# Altium schematic sheet SENSOR_and_Input_Output V1.0.SchDoc("SENSOR_and_Input_Output V1.0")
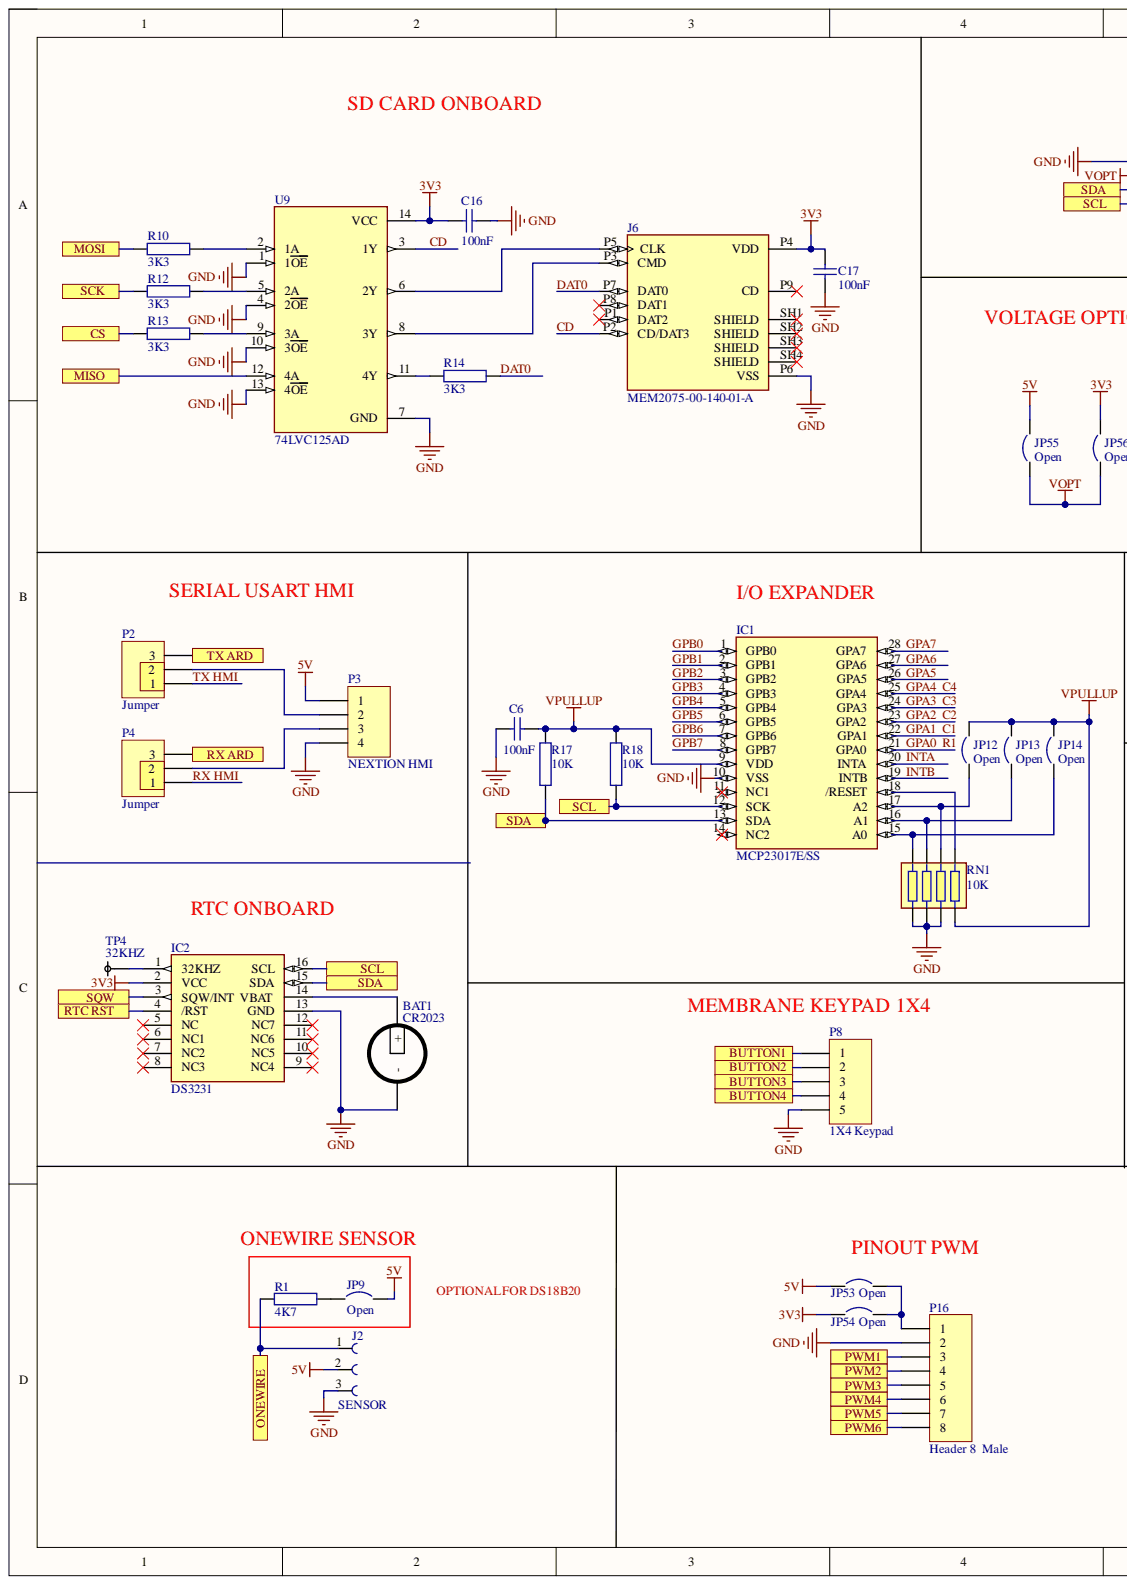
[diagram p0: SENSOR_and_Input_Output V1.0.SchDoc, left]
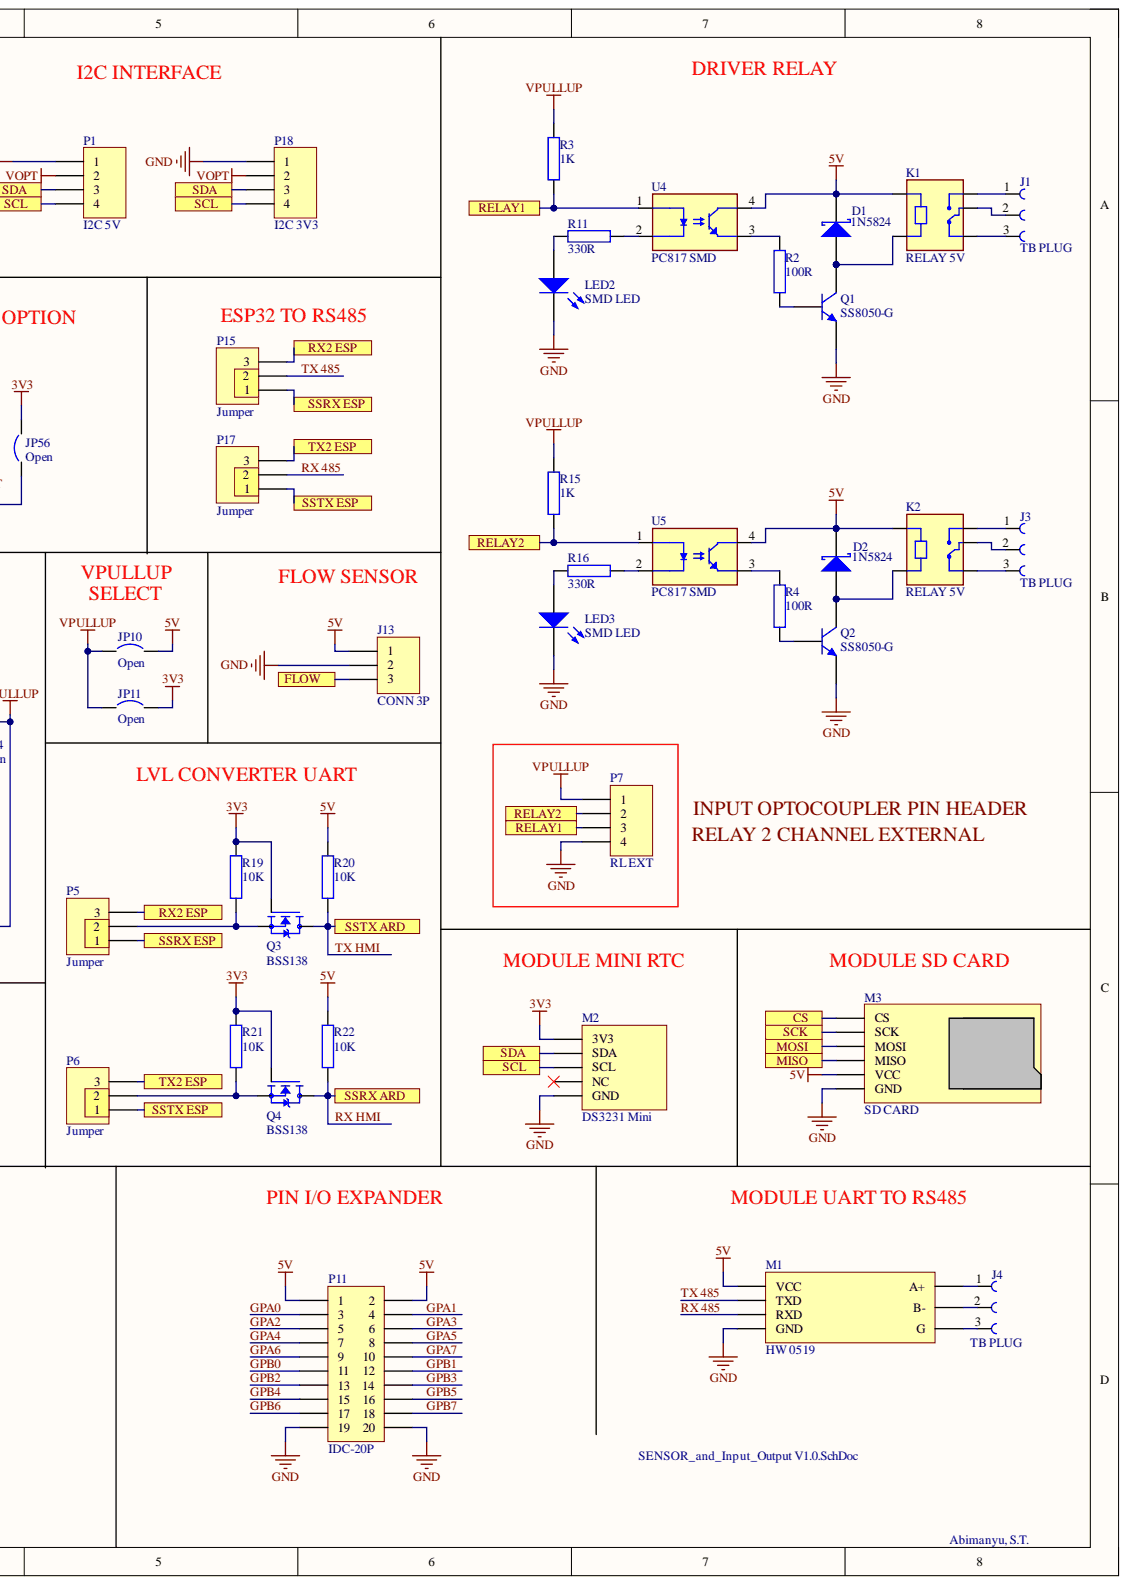
[diagram p1: SENSOR_and_Input_Output V1.0.SchDoc, right]

## Parts
BAT1  CR2023  [BATT CR2023 VERTICAL]
C6  100nF  [CAP-0805_Capacitor Non Polar]
C16  100nF  [CAP-0805_Capacitor Non Polar]
C17  100nF  [CAP-0805_Capacitor Non Polar]
D1  1N5824  [DO-214AC]
D2  1N5824  [DO-214AC]
IC1  MCP23017E/SS  [SSOP-28]
IC2  DS3231  [SOIC-16]
J1  TB PLUG  [TB PLUG 3P 5mm]
J2  SENSOR  [TB PLUG 3P 5mm]
J3  TB PLUG  [TB PLUG 3P 5mm]
J4  TB PLUG  [TB PLUG 3P 5mm]
J6  MEM2075-00-140-01-A  [GCT_MEM2075-00-140-01-A]
J13  CONN 3P  [CONN HDR 3P TH]
JP9  Open  [SB_STM]
JP10  Open  [SB_STM]
JP11  Open  [SB_STM]
JP12  Open  [SB_STM]
JP13  Open  [SB_STM]
JP14  Open  [SB_STM]
JP53  Open  [SB_STM]
JP54  Open  [SB_STM]
JP55  Open  [SB_STM]
JP56  Open  [SB_STM]
K1  RELAY 5V  [RELAY_SONGLE-05VDC-SL-C]
K2  RELAY 5V  [RELAY_SONGLE-05VDC-SL-C]
LED2  SMD LED  [LED SMT 1608 (0603)]
LED3  SMD LED  [LED SMT 1608 (0603)]
M1  HW 0519  [HW 0519]
M2  DS3231 Mini  [Module DS3231 Mini RTC RIVERSED]
M3  SD CARD  [MODULE SD CARD]
P1  I2C 5V  [CONN HDR 4P TH]
P2  Jumper  [Jumper Pin, 3-Pin]
P3  NEXTION HMI  [CONN HDR 4P TH]
P4  Jumper  [Jumper Pin, 3-Pin]
P5  Jumper  [Jumper Pin, 3-Pin]
P6  Jumper  [Jumper Pin, 3-Pin]
P7  RL EXT  [Pin Header 1x4 TH Pitch 2.54mm]
P8  1X4 Keypad  [Pin Header 1x5 TH Pitch 2.54mm]
P11  IDC-20P  [IDC-20P]
P15  Jumper  [Jumper Pin, 3-Pin]
P16  Header 8  Male  [Pin Header 1x8 TH Pitch 2.54mm]
P17  Jumper  [Jumper Pin, 3-Pin]
P18  I2C 3V3  [CONN HDR 4P TH]
Q1  SS8050-G  [TO-236]
Q2  SS8050-G  [TO-236]
Q3  BSS138  [SOT-23]
Q4  BSS138  [SOT-23]
R1  4K7  [1608_[0603] Resistor]
R2  100R  [1608_[0603] Resistor]
R3  1K  [1608_[0603] Resistor]
R4  100R  [1608_[0603] Resistor]
R10  3K3  [RESITOR 0805]
R11  330R  [1608_[0603] Resistor]
R12  3K3  [RESITOR 0805]
R13  3K3  [RESITOR 0805]
R14  3K3  [RESITOR 0805]
R15  1K  [1608_[0603] Resistor]
R16  330R  [1608_[0603] Resistor]
R17  10K  [RESITOR 0805]
R18  10K  [RESITOR 0805]
R19  10K  [RESITOR 0805]
R20  10K  [RESITOR 0805]
R21  10K  [RESITOR 0805]
R22  10K  [RESITOR 0805]
RN1  10K  [RES 4ARR 0603]
TP4  32KHZ  [PAD_HOLE]
U4  PC817 SMD  [SMD-4]
U5  PC817 SMD  [SMD-4]
U9  74LVC125AD  [SOIC14]

## Ports
BUTTON1, BUTTON2, BUTTON3, BUTTON4, CS, FLOW, MISO, MOSI, ONEWIRE, PWM1, PWM2, PWM3, PWM4, PWM5, PWM6, RELAY1, RELAY2, RTC RST, RX2 ESP, RX ARD, SCK, SCL, SDA, SQW, SSRX ARD, SSRX ESP, SSTX ARD, SSTX ESP, TX2 ESP, TX ARD

## Nets
3V3: C16.2 C17.2 IC2.2(VCC) J6.P4(VDD) JP11.2 JP54.1 JP56.2 M2.5(3V3) Q3.1 Q4.1 R19.2 R21.2 U9.14(VCC)
5V: D1.C D2.C J2.2 J13.1 JP9.2 JP10.2 JP53.1 JP55.2 K1.A1 K2.A1 M1.VCC M3.VCC P3.1 P11.1 P11.2 R20.2 R22.2 U4.4 U5.4
GND: BAT1.- C6.1 C16.1 C17.1 IC1.10(VSS) IC2.13(GND) J2.3 J6.P6(VSS) J13.2 LED2.2 LED3.2 M1.GND M2.1(GND) M3.GND P1.1 P3.4 P7.4 P8.5 P11.19 P11.20 P16.2 P18.1 Q1.2 Q2.2 RN1.1 RN1.2 RN1.3 U9.1(1OE) U9.4(2OE) U9.7(GND) U9.10(3OE) U9.13(4OE)
VOPT: JP55.1 JP56.1 P1.2 P18.2
VPULLUP: C6.2 IC1.9(VDD) JP10.1 JP11.1 JP12.2 JP13.2 JP14.2 P7.1 R3.1 R15.1 R17.2 R18.2 RN1.4
BUTTON1: P8.1 ; port BUTTON1
BUTTON2: P8.2 ; port BUTTON2
BUTTON3: P8.3 ; port BUTTON3
BUTTON4: P8.4 ; port BUTTON4
C1: IC1.22(GPA1) P11.4
C2: IC1.23(GPA2) P11.5
C3: IC1.24(GPA3) P11.6
C4: IC1.25(GPA4) P11.7
CD: J6.P2(CD/DAT3) U9.3(1Y)
CS: M3.CS R13.1 ; port CS
DAT0: J6.P7(DAT0) R14.2
FLOW: J13.3 ; port FLOW
GPA0: IC1.21(GPA0) P11.3
GPA5: IC1.26(GPA5) P11.8
GPA6: IC1.27(GPA6) P11.9
GPA7: IC1.28(GPA7) P11.10
GPB0: IC1.1(GPB0) P11.11
GPB1: IC1.2(GPB1) P11.12
GPB2: IC1.3(GPB2) P11.13
GPB3: IC1.4(GPB3) P11.14
GPB4: IC1.5(GPB4) P11.15
GPB5: IC1.6(GPB5) P11.16
GPB6: IC1.7(GPB6) P11.17
GPB7: IC1.8(GPB7) P11.18
INTA: IC1.20(INTA)
INTB: IC1.19(INTB)
MISO: M3.MISO U9.12(4A) ; port MISO
MOSI: M3.MOSI R10.1 ; port MOSI
ONEWIRE: J2.1 R1.1 ; port ONEWIRE
PWM1: P16.3 ; port PWM1
PWM2: P16.4 ; port PWM2
PWM3: P16.5 ; port PWM3
PWM4: P16.6 ; port PWM4
PWM5: P16.7 ; port PWM5
PWM6: P16.8 ; port PWM6
RELAY1: P7.3 R3.2 U4.1 ; port RELAY1
RELAY2: P7.2 R15.2 U5.1 ; port RELAY2
RTC RST: IC2.4(/RST) ; port RTC RST
RX2 ESP: P5.3 P15.3 ; port RX2 ESP
RX 485: M1.RXD P17.2
RX ARD: P4.3 ; port RX ARD
RX HMI: P4.1 Q4.3 R22.1 ; port SSRX ARD
SCK: M3.SCK R12.1 ; port SCK
SCL: IC1.12(SCK) IC2.16(SCL) M2.3(SCL) P1.4 P18.4 R18.1 ; port SCL
SDA: IC1.13(SDA) IC2.15(SDA) M2.4(SDA) P1.3 P18.3 R17.1 ; port SDA
SQW: IC2.3(SQW/INT) ; port SQW
SSRX ESP: P5.1 P15.1 ; port SSRX ESP
SSTX ESP: P6.1 P17.1 ; port SSTX ESP
TX2 ESP: P6.3 P17.3 ; port TX2 ESP
TX 485: M1.TXD P15.2
TX ARD: P2.3 ; port TX ARD
TX HMI: P2.1 Q3.3 R20.1 ; port SSTX ARD
NetBAT1_+: BAT1.+ IC2.14(VBAT)
NetD1_A: D1.A K1.A2 Q1.3
NetD2_A: D2.A K2.A2 Q2.3
NetIC1_15: IC1.15(A0) JP14.1 RN1.8
NetIC1_16: IC1.16(A1) JP13.1 RN1.7
NetIC1_17: IC1.17(A2) JP12.1 RN1.6
NetIC1_18: IC1.18(/RESET) RN1.5
NetIC2_1: IC2.1(32KHZ) TP4.1
NetJ1_1: J1.1 K1.NO
NetJ1_2: J1.2 K1.COM
NetJ1_3: J1.3 K1.NC
NetJ3_1: J3.1 K2.NO
NetJ3_2: J3.2 K2.COM
NetJ3_3: J3.3 K2.NC
NetJ4_1: J4.1 M1.a+(A+)
NetJ4_2: J4.2 M1.B-
NetJ4_3: J4.3 M1.485(G)
NetJ6_P3: J6.P3(CMD) U9.8(3Y)
NetJ6_P5: J6.P5(CLK) U9.6(2Y)
NetJP9_1: JP9.1 R1.2
NetJP53_2: JP53.2 JP54.2 P16.1
NetLED2_1: LED2.1 R11.2
NetLED3_1: LED3.1 R16.2
NetP2_2: P2.2 P3.2
NetP3_3: P3.3 P4.2
NetP5_2: P5.2 Q3.2 R19.1
NetP6_2: P6.2 Q4.2 R21.1
NetQ1_1: Q1.1 R2.1
NetQ2_1: Q2.1 R4.1
NetR2_2: R2.2 U4.3
NetR4_2: R4.2 U5.3
NetR10_2: R10.2 U9.2(1A)
NetR11_1: R11.1 U4.2
NetR12_2: R12.2 U9.5(2A)
NetR13_2: R13.2 U9.9(3A)
NetR14_1: R14.1 U9.11(4Y)
NetR16_1: R16.1 U5.2

Not connected: IC1 11(NC1) 14(NC2); IC2 5(NC) 6(NC1) 7(NC2) 8(NC3) 9(NC4) 10(NC5) 11(NC6) 12(NC7); J6 P1(DAT2) P8(DAT1) P9(CD) SH1(SHIELD) SH2(SHIELD) SH3(SHIELD) SH4(SHIELD); M2 2(NC)

## Library data of the parts
BAT1 = BATT CR2023 VERTICAL: BATTERY HOLDER; Manufacturer: Keystone; Part Number: 1056; Batt Size: CR1220; Component Kind: Standard; Library Name: AB_Lib.SchLib; PackageReference: Header Double Pin
C6 C16 C17 = Capacitor 0805 (Inch): 0805 SMD Non-Polarity Capacitor; Component Kind: Standard; Library Name: AB_Lib.SchLib
D1 D2 = 1N5824 SMD: Maximum repetitive peak reverse voltage 40v  Maximum average forward rectified current 5.0A  Peak forward surge current 8.3 mS 100A  Voltage rate of change 10000 V/uS  Operating junction temperature range -55 to +150 C; MF: Diodes Incorporated; MP: US1M-13; Availability: In Stock; Check_prices: https://www.snapeda.com/parts/US1M-13/Diodes+Inc./view-part/?ref=eda; Component Kind: Standard; Library Name: AB_Lib.SchLib; Package: DO-214AC-214 Diodes Incorporated; Price: None; SnapEDA_Link: https://www.snapeda.com/parts/US1M-13/Diodes+Inc./view-part/?ref=snap
IC1 = MCP23017E/SS: I/O Expander 16 IÂ²C 10MHz 28-SSOP; MF: Microchip Technology; MP: MCP23017-E/SS; Availability: In Stock; Check_prices: https://www.snapeda.com/parts/MCP23017-E/SS/Microchip/view-part/5532973/?ref=eda; Component Kind: Standard; Library Name: AB_Lib.SchLib; Package: SSOP-28 Microchip; Price: None; SnapEDA_Link: https://www.snapeda.com/parts/MCP23017-E/SS/Microchip/view-part/5532973/?ref=snap
IC2 = DS3231: Real Time Clock (RTC) IC Clock/Calendar - IÂ²C, 2-Wire Serial 8-SOIC (0.154, 3.90mm Width); MF: Maxim Integrated; Availability: In Stock; Check_prices: https://www.snapeda.com/parts/DS3231/Maxim+Integrated/view-part/?ref=eda; Component Kind: Standard; Library Name: AB_Lib.SchLib; MP: DS3231; Package: SOIC-16 Maxim Integrated; Price: None; Purchase-URL: https://pricing.snapeda.com/search/part/DS3231/?ref=eda; SnapEDA_Link: https://www.snapeda.com/parts/DS3231/Maxim+Integrated/view-part/?ref=snap
J1-J4 = TB PLUG 3P 5M: MF: Wurth Elektronik; MP: 691312710002; Availability: In Stock; Check_prices: https://www.snapeda.com/parts/691312710002/Wurth+Elektronik/view-part/?ref=eda; Component Kind: Standard; Description: TB PLUG 3P 5M; Library Name: AB_Lib.SchLib; Package: None; Price: None; Purchase-URL: https://pricing.snapeda.com/search/part/691312710002/?ref=eda; SnapEDA_Link: https://www.snapeda.com/parts/691312710002/Wurth+Elektronik/view-part/?ref=snap
J6 = MEM2075-00-140-01-A: Micro SD Memory Card Connector, 1.4mm Profile SMT, Push-Push, with Normally open Switch; Availability: Unavailable; Component Kind: Standard; Library Name: AB_Lib.SchLib; MP: MEM2075-00-140-01-A; Package: None; Price: None
J13 = CONN HDR 3P TH: Connector Header Through Hole 3 position 0.098 (2.50mm); Component Kind: Standard; LatestRevisionDate: 17-Jul-2002; LatestRevisionNote: Re-released for DXP Platform.; Library Name: AB_Lib.SchLib; Publisher: Altium Limited
JP9-JP14 JP53-JP56 = Jumper Solder: Jumper Solder Pad; Component Kind: Standard; Library Name: AB_Lib.SchLib; Published: 24-Mar-1999; Revision: July-2002: Re-released for DXP Platform.; Publisher: Altium Limited
K1 K2 = RELAY SONGLE 5V: 5V Trigger Relay Module For Arduino And Raspberry Pi 5V Trigger Relay Module For Arduino And Raspberry Pi; MF: Songle Relay; MP: SRD-05VDC-SL-C; Availability: Not in stock; Check_prices: https://www.snapeda.com/parts/SRD-05VDC-SL-C/Songle+Relay/view-part/?ref=eda; Component Kind: Standard; Library Name: AB_Lib.SchLib; Package: NON STANDARD-5 Songle Relay; Price: None; SnapEDA_Link: https://www.snapeda.com/parts/SRD-05VDC-SL-C/Songle+Relay/view-part/?ref=snap
LED2 LED3 = LED SMT BLUE: 0603 BLUE LED; Component Kind: Standard; LatestRevisionDate: 08-Jul-2005; LatestRevisionNote: Mech Layer 1 removed.; Library Name: AB_Lib.SchLib; PackageDescription: LED; 2 Leads; PackageReference: LED-0; Published: 8-Jun-2000; Publisher: Altium Limited
M1 = HW 0519: RS485 TO UART; Component Kind: Standard; LatestRevisionDate: 17-Jul-2002; LatestRevisionNote: Re-released for DXP Platform.; Library Name: AB_Lib.SchLib; Publisher: Altium Limited
M2 = Module RIVERSED DS3231 Mini RTC for Pi: Mini RTC; Component Kind: Standard; LatestRevisionDate: 17-Jul-2002; LatestRevisionNote: Re-released for DXP Platform.; Library Name: AB_Lib.SchLib; Publisher: Altium Limited
M3 = Module SD CARD: Component Kind: Standard; Description: Module SD CARD; LatestRevisionDate: 17-Jul-2002; LatestRevisionNote: Re-released for DXP Platform.; Library Name: AB_Lib.SchLib; Publisher: Altium Limited
P1 P3 P18 = CONN HDR 4P TH: CONN HEADER 4P TH; Component Kind: Standard; LatestRevisionDate: 17-Jul-2002; LatestRevisionNote: Re-released for DXP Platform.; Library Name: AB_Lib.SchLib; Publisher: Altium Limited
P2 P4-P6 P15 P17 = Jumper Pin, 3-Pin: Component Kind: Standard; Description: Jumper Pin, 3-Pin; LatestRevisionDate: 17-Jul-2002; LatestRevisionNote: Re-released for DXP Platform.; Library Name: AB_Lib.SchLib; Publisher: Altium Limited
P7 = Header 4  Male: Header, 4-Pin; Component Kind: Standard; LatestRevisionDate: 17-Jul-2002; LatestRevisionNote: Re-released for DXP Platform.; Library Name: AB_Lib.SchLib; Publisher: Altium Limited
P8 = Header 5  Male: Header, 5-Pin; Component Kind: Standard; LatestRevisionDate: 17-Jul-2002; LatestRevisionNote: Re-released for DXP Platform.; Library Name: AB_Lib.SchLib; Publisher: Altium Limited
P16 = Header 8  Male: Header, 8-Pin; Component Kind: Standard; LatestRevisionDate: 17-Jul-2002; LatestRevisionNote: Re-released for DXP Platform.; Library Name: AB_Lib.SchLib; Publisher: Altium Limited
Q1 Q2 = TR SS8050-G: Bipolar (BJT) Transistor NPN 25 V 1.5 A 100MHz 300 mW Surface Mount SOT-23-3; Code_JEDEC: TO-226-AA; Component Kind: Standard; LatestRevisionDate: 17-Jul-2002; LatestRevisionNote: Re-released for DXP Platform.; Library Name: AB_Lib.SchLib; PackageDescription: TO, Flat Index; 3 In-Line, Axial Leads; Body Dia. 4.8mm; Leads 0.55 x 0.51 mm (max); PackageReference: TO-226-AA; Published: 8-Jun-2000; Publisher: Altium Limited
Q3 Q4 = BSS138: N-CH MOSFET 50V 0.34A SOT-23-3L; MF: Diodes Inc.; Availability: In Stock; Check_prices: https://www.snapeda.com/parts/BSS138/Diodes+Inc./view-part/?ref=eda; Component Kind: Standard; Library Name: AB_Lib.SchLib; MP: BSS138; Package: SOT-23-3 Diodes Inc.; Price: None; Purchase-URL: https://pricing.snapeda.com/search/part/BSS138/?ref=eda; SnapEDA_Link: https://www.snapeda.com/parts/BSS138/Diodes+Inc./view-part/?ref=snap
R1 R10 R12-R14 R17-R22 = Resistor 0805 (Inch): 0805 SMD Thick Resistor; Component Kind: Standard; Library Name: AB_Lib.SchLib
R2-R4 R11 R15 R16 = Resistor 1206 (Inch): 1206 SMD Thick Resistor; Component Kind: Standard; Library Name: AB_Lib.SchLib
RN1 = RES 4ARR 0603: Resistor Array, Chip, 4 elements CONVEX 1206 2%; MF: Panasonic; MP: EXB-38V330GV; Availability: Bad; Check_prices: https://www.snapeda.com/parts/EXB-38V330GV/Panasonic/view-part/1421932/?ref=eda; Component Kind: Standard; Library Name: AB_Lib.SchLib; Package: 0603 Panasonic; Price: None; SnapEDA_Link: https://www.snapeda.com/parts/EXB-38V330GV/Panasonic/view-part/1421932/?ref=snap
TP4 = Test Point: Thick Pad; Component Kind: Standard; Library Name: AB_Lib.SchLib
U4 U5 = PC817 SMD: Optoisolator Transistor Output 1 Channel 4-DIP; MF: Sharp Microelectronics; MP: PC817X3NSZ1B; Availability: Good; Check_prices: https://www.snapeda.com/parts/PC817X3NSZ1B/Sharp%20Microelectronics/view-part/5163575/?ref=eda; Component Kind: Standard; Library Name: AB_Lib.SchLib; Package: None; Price: None; Purchase-URL: https://pricing.snapeda.com/search/part/PC817X3NSZ1B/?ref=eda; SnapEDA_Link: https://www.snapeda.com/parts/PC817X3NSZ1B/Sharp%20Microelectronics/view-part/5163575/?ref=snap
U9 = 74LVC125AD: Buffer/Line Driver 4-CH Non-Inverting 3-ST CMOS 14-Pin SO; MF: NXP Semiconductors; Availability: In Stock; Check_prices: https://www.snapeda.com/parts/74LVC125AD/NXP+Semiconductors/view-part/?ref=eda; Component Kind: Standard; Library Name: AB_Lib.SchLib; MP: 74LVC125AD; Package: SOIC-14 NXP Semiconductors; Price: None; Purchase-URL: https://pricing.snapeda.com/search/part/74LVC125AD/?ref=eda; SnapEDA_Link: https://www.snapeda.com/parts/74LVC125AD/NXP+Semiconductors/view-part/?ref=snap
P11 = IDC-20P: Component Kind: Standard; Description: IDC-20P; LatestRevisionDate: 17-Jul-2002; LatestRevisionNote: Re-released for DXP Platform.; Library Name: AB_Lib.SchLib; Publisher: Altium Limited
